# Altium schematic sheet 05_Power_FUSE.SchDoc(05_Power_FUSE)
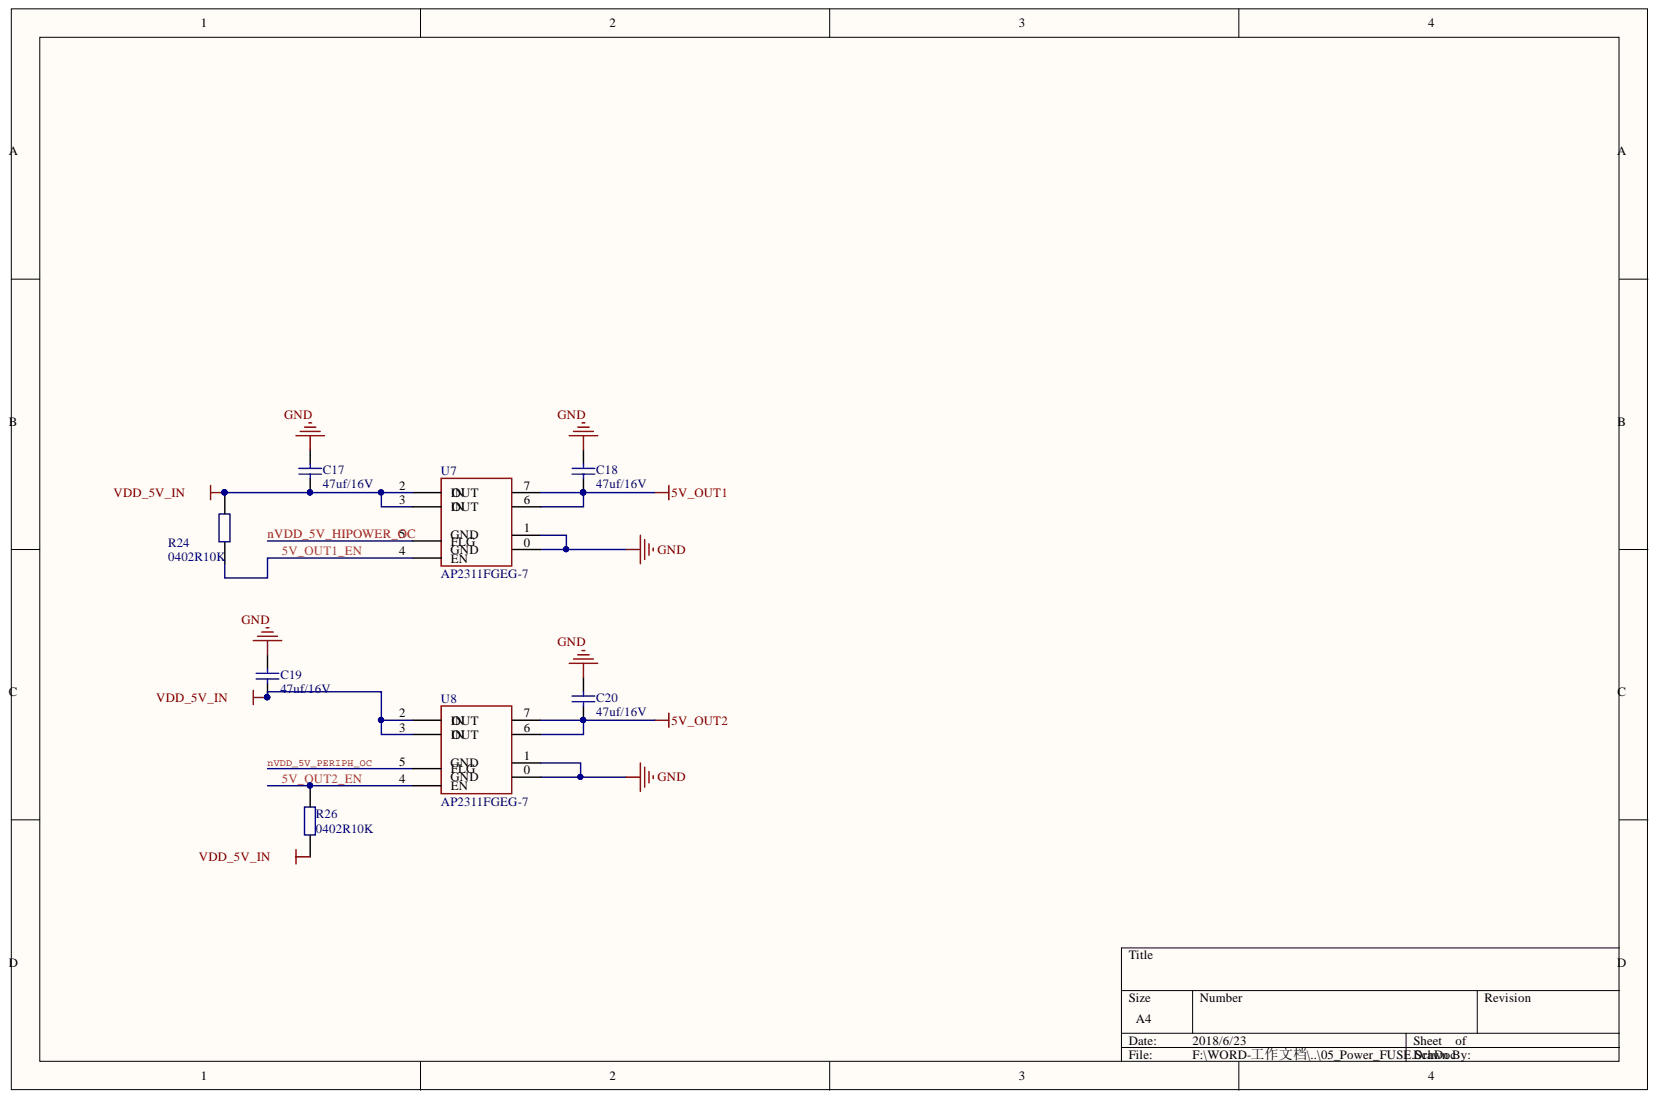
[diagram p0: 05_Power_FUSE.SchDoc]

## Parts
C17  47uf/16V  [0805CAP]
C18  47uf/16V  [0805CAP]
C19  47uf/16V  [0805CAP]
C20  47uf/16V  [0805CAP]
R24  0402R10K  [0402R]
R26  0402R10K  [0402R]
U7  AP2311FGEG-7  [QFN8_0.65]
U8  AP2311FGEG-7  [QFN8_0.65]

## Nets
5V_OUT1: C18.1 U7.6 U7.7
5V_OUT2: C20.1 U8.6 U8.7
GND: C17.2 C18.2 C19.2 C20.2 U7.0 U7.1 U8.0 U8.1
VDD_5V_IN: C17.1 C19.1 R24.2 R26.2 U7.2(IN) U7.3(IN) U8.2(IN) U8.3(IN)
5V_OUT1_EN: R24.1 U7.4(EN)
5V_OUT2_EN: R26.1 U8.4(EN)
NVDD_5V_HIPOWER_OC: U7.5(GND)
NVDD_5V_PERIPH_OC: U8.5(GND)

## Library data of the parts
C17-C20 = Cap: Capacitor; Component Kind: Standard; Library Name: Miscellaneous Devices.IntLib; Signal Integrity: Cap; Simulation: CAP
R24 R26 = 0402R1K: 0402R1K 1%; Component Kind: Standard; Library Name: CUAV.SchLib
U7 U8 = AP2311FGEG-7: Component Kind: Standard; Library Name: CUAV.SchLib
